# Altium schematic sheet wulianwangwenhengmokuai.SchDoc(wulianwangwenhengmokuai)
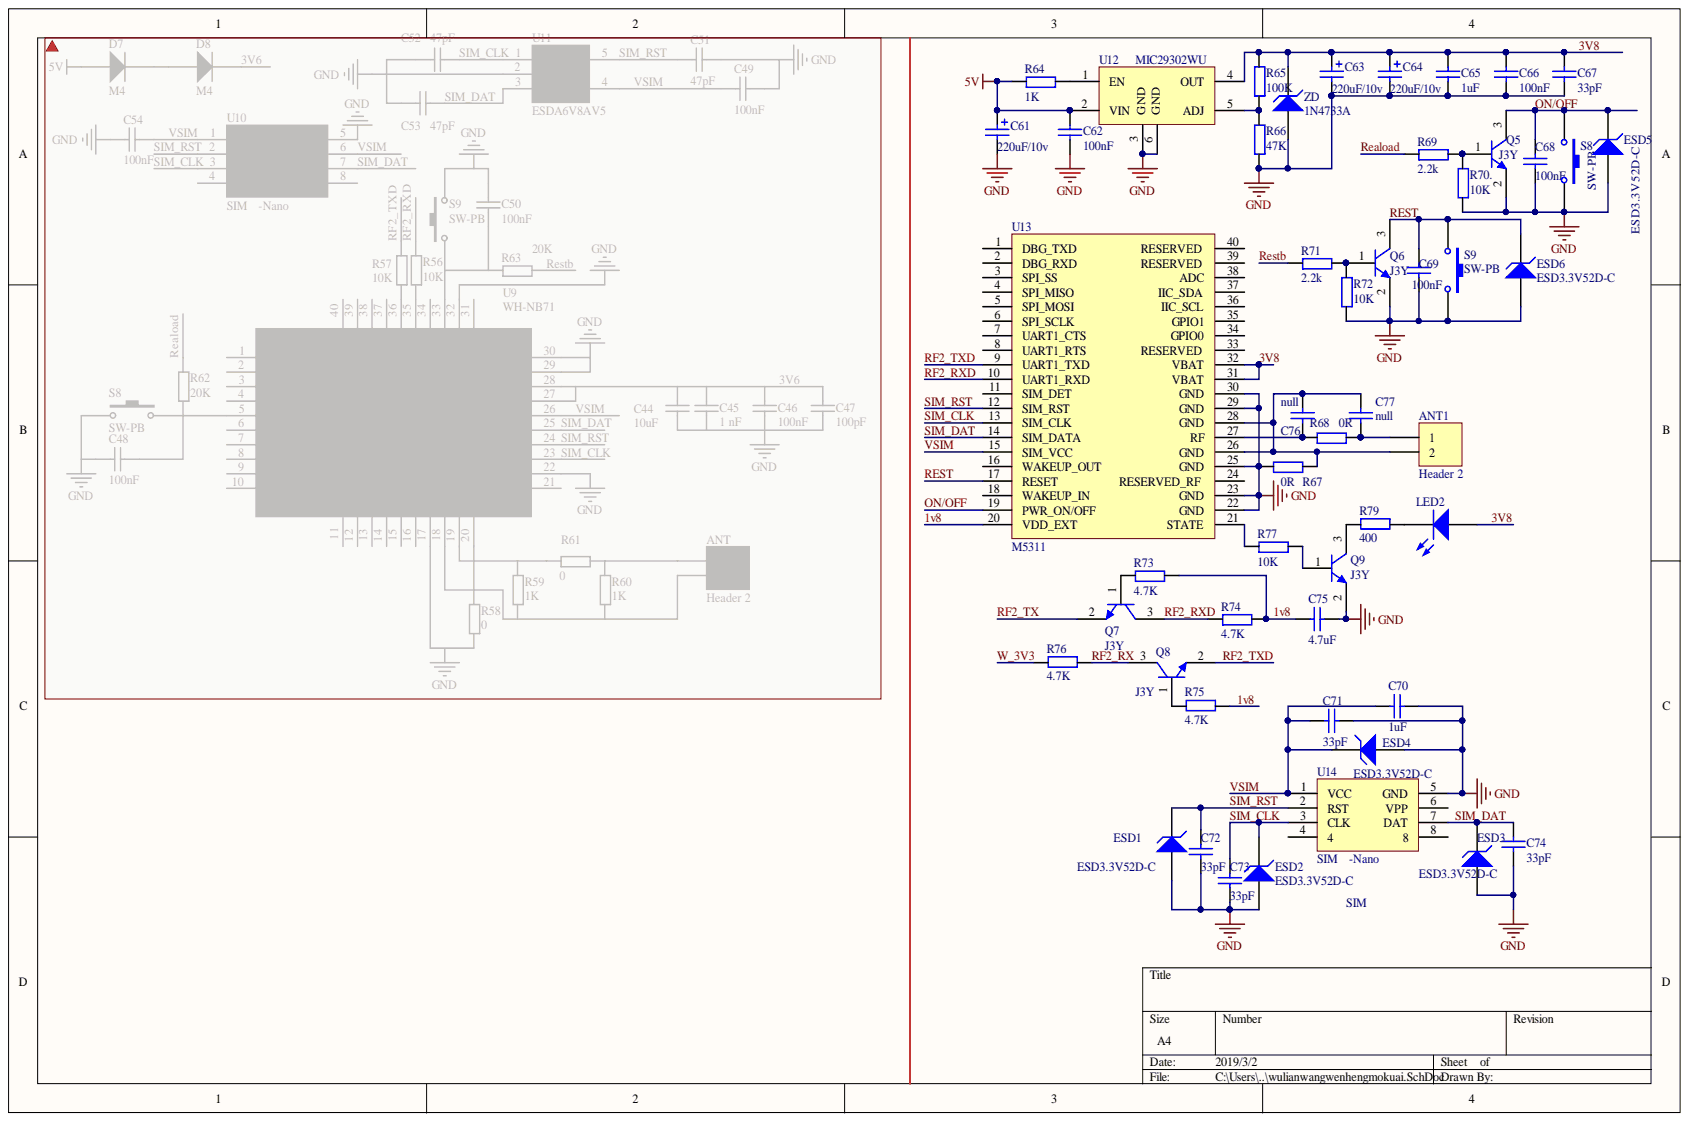
[diagram p0: wulianwangwenhengmokuai.SchDoc]

## Parts
ANT1  Header 2  [SMA-W]
C61  220uF/10v  [C5X8]
C62  100nF  [C0805]
C63  220uF/10v  [C5X8]
C64  220uF/10v  [C5X8]
C65  1uF  [C0805]
C66  100nF  [C0805]
C67  33pF  value 100nF  [C0805]
C68  100nF  [C0603]
C69  100nF  [C0603]
C70  1uF  [C0603]
C71  33pF  [C0603]
C72  33pF  [C0603]
C73  33pF  [C0603]
C74  33pF  [C0603]
C75  4.7uF  [C0603]
C76  null  [C0603]
C77  null  [C0603]
ESD1  ESD3.3V52D-C  [C0603]
ESD2  ESD3.3V52D-C  [C0603]
ESD3  ESD3.3V52D-C  [C0603]
ESD4  ESD3.3V52D-C  [C0603]
ESD5  ESD3.3V52D-C  [C0603]
ESD6  ESD3.3V52D-C  [C0603]
LED2  网络指示  [LED0805]  (value not drawn)
Q5  J3Y  [SOT-23]
Q6  J3Y  [SOT-23]
Q7  J3Y  [SOT-23]
Q8  J3Y  [SOT-23]
Q9  J3Y  [SOT-23]
R64  1K  [R0805]
R65  100K  [R0805]
R66  47K  [R0805]
R67  0R  [R0603]
R68  0R  [R0603]
R69  2.2k  [R0603]
R70.  10K  [R0603]
R71  2.2k  [R0603]
R72  10K  [R0603]
R73  4.7K  [R0603]
R74  4.7K  [R0603]
R75  4.7K  [R0603]
R76  4.7K  [R0603]
R77  10K  [R0603]
R79  400  [R0603]
S8  SW-PB  [3X6X2.5KEY-M]
S9  SW-PB  [3X6X2.5KEY-M]
U12  MIC29302WU  [TO263-5]
U13  M5311  [M5311]
U14  SIM卡-Nano  [SIM-Nano]  (value not drawn)
ZD  1N4733A  [SMA]

## Nets
5V: C61.1 C62.2 R64.1 U12.2(VIN)
GND: C61.2 C62.1 C63.2 C64.2 C65.1 C66.1 C67.1 C68.1 C69.1 C70.1 C71.1 C72.2 C73.2 C74.2 C75.1 ESD1.1 ESD2.1 ESD3.1 ESD4.1 ESD5.1 ESD6.1 Q5.2 Q6.2 Q9.2 R66.1 R67.1 R70..1 R72.1 S8.2 S9.2 U12.3(GND) U12.6(GND) U13.22(GND) U13.23(GND) U13.25(GND) U13.29(GND) U13.30(GND) U14.5(GND) ZD.1
1v8: C75.2 R73.2 R74.2 R75.1 U13.20(VDD_EXT)
3V8: C63.1 C64.1 C65.2 C66.2 C67.2 LED2.1 R65.2 U12.4(OUT) U13.31(VBAT) U13.32(VBAT) ZD.2
ON/OFF: C68.2 ESD5.2 Q5.3 S8.1 U13.19(PWR_ON/OFF)
Reaload: R69.1
REST: C69.2 ESD6.2 Q6.3 S9.1 U13.17(RESET)
Restb: R71.1
RF2_RX: Q8.3 R76.1
RF2_RXD: Q7.3 R74.1 U13.10(UART1_RXD)
RF2_TX: Q7.2
RF2_TXD: Q8.2 U13.9(UART1_TXD)
SIM_CLK: C73.1 ESD2.2 U13.13(SIM_CLK) U14.3(CLK)
SIM_DAT: C74.1 ESD3.2 U13.14(SIM_DATA) U14.7(DAT)
SIM_RST: C72.1 ESD1.2 U13.12(SIM_RST) U14.2(RST)
VSIM: C70.2 C71.2 ESD4.2 U13.15(SIM_VCC) U14.1(VCC)
W_3V3: R76.2
NetANT1_1: ANT1.1 C77.2 R68.2
NetANT1_2: ANT1.2 C76.1 C77.1 R67.2 U13.26(GND) U13.28(GND)
NetC76_2: C76.2 R68.1 U13.27(RF)
NetLED2_2: LED2.2 R79.1
NetQ5_1: Q5.1 R69.2 R70..2
NetQ6_1: Q6.1 R71.2 R72.2
NetQ7_1: Q7.1 R73.1
NetQ8_1: Q8.1 R75.2
NetQ9_1: Q9.1 R77.2
NetQ9_3: Q9.3 R79.2
NetR64_2: R64.2 U12.1(EN)
NetR65_1: R65.1 R66.2 U12.5(ADJ)
NetR77_1: R77.1 U13.21(STATE)
N00050:

Not connected: U13 1(DBG_TXD) 2(DBG_RXD) 3(SPI_SS) 4(SPI_MISO) 5(SPI_MOSI) 6(SPI_SCLK) 7(UART1_CTS) 8(UART1_RTS) 11(SIM_DET) 16(WAKEUP_OUT) 18(WAKEUP_IN) 24(RESERVED_RF) 33(RESERVED) 34(GPIO0) 35(GPIO1) 36(IIC_SCL) 37(IIC_SDA) 38(ADC) 39(RESERVED) 40(RESERVED); U14 4 6(VPP) 8

## Library data of the parts
ANT1 = Header 2: 天线座子，弯头，总长17mm，牙长11mm; Signal Integrity: Connector; LatestRevisionNote: Re-released for DXP Platform.; LatestRevisionDate: 17-Jul-2002; Component Kind: Standard; Publisher: Altium Limited; Library Name: 温湿度第三版.SCHLIB
C61 C63 C64 = Cap Pol1_1: Polarized Capacitor (Radial); Value: 220uF/10v; Simulation: CAP; Signal Integrity: Cap Pol; Published: 8-Jun-2000; PackageReference: RB7.6-15; PackageDescription: Polarized Capacitor (Radial); 2 Leads; LatestRevisionNote: Re-released for DXP Platform.; LatestRevisionDate: 17-Jul-2002; Component Kind: Standard; Publisher: Altium Limited; Library Name: 温湿度第三版.SCHLIB
C62 C66-C69 = Cap: Capacitor; Simulation: CAP; Signal Integrity: Cap; Published: 8-Jun-2000; PackageReference: RAD-0.3; PackageDescription: Radial Cap, Thru-Hole; 2 Leads; 0.3 in Pin Spacing; LatestRevisionNote: Re-released for DXP Platform.; LatestRevisionDate: 17-Jul-2002; Component Kind: Standard; Publisher: Altium Limited; Library Name: 温湿度第三版.SCHLIB
  C62 C66 C68 C69: Value: 100nF
C65 C70-C77 = Cap: Capacitor; Value: 100nF; Simulation: CAP; Signal Integrity: Cap; Published: 8-Jun-2000; PackageReference: RAD-0.3; PackageDescription: Radial Cap, Thru-Hole; 2 Leads; 0.3 in Pin Spacing; LatestRevisionNote: Re-released for DXP Platform.; LatestRevisionDate: 17-Jul-2002; Component Kind: Standard; Publisher: Altium Limited; Library Name: 温湿度第三版.SCHLIB
ESD1-ESD6 = D Zener: ESD保护器件; Simulation: ZENER; Signal Integrity: D Zener; Published: 8-Jun-2000; PackageReference: DIODE-0.7; PackageDescription: Diode, Thru-Hole; 2 Leads; 0.7 in Pin Spacing; Library Name: Miscellaneous Devices.IntLib; LatestRevisionNote: Re-released for DXP Platform.; LatestRevisionDate: 17-Jul-2002; Component Kind: Standard; Publisher: Altium Limited
LED2 = LED2: Typical RED, GREEN, YELLOW, AMBER GaAs LED; Simulation: LED2; Published: 8-Jun-2000; PackageReference: 3.2X1.6X1.1; PackageDocument: Feb-2002; LatestRevisionNote: Re-released for DXP Platform.; LatestRevisionDate: 17-Jul-2002; Component Kind: Standard; Publisher: Altium Limited; Library Name: 战舰STM32开发板底板.IntLib
Q5-Q9 = 2N3904: NPN General Purpose Amplifier; Simulation: 2N3904; Signal Integrity: 2N3904; Published: 8-Jun-2000; PackageVersion: Sep-1998; PackageReference: TO-92A; PackageDescription: TO, Flat Index; 3 In-Line, Axial Leads; Body Dia. 4.5mm; Leads 0.51 x 0.48 mm (max); LatestRevisionNote: PCB Footprint 'BCY-W3/D4.7' replaced; LatestRevisionDate: 15-Jan-2003; DatasheetVersion: 1997; ComponentLink2URL: http://www.fairchildsemi.com/ds/2N%2F2N3904.pdf; ComponentLink2Description: Datasheet; ComponentLink1URL: http://www.fairchildsemi.com/; ComponentLink1Description: Manufacturer Link; Component Kind: Standard; Publisher: Altium Limited; Library Name: 温湿度第三版.SCHLIB
R64-R69 R70. R71-R77 R79 = R: Component Kind: Standard; Library Name: 温湿度第三版.SCHLIB
S8 = SW-PB_1: Switch; Published: 24-Mar-1999; PackageReference: SPST-2; PackageDescription: Switch; 2 Leads; LatestRevisionNote: Re-released for DXP Platform.; LatestRevisionDate: 17-Jul-2002; Component Kind: Standard; Publisher: Altium Limited; Library Name: 温湿度第三版.SCHLIB
S9 = SW-PB: Switch; Published: 24-Mar-1999; PackageReference: SPST-2; PackageDescription: Switch; 2 Leads; LatestRevisionNote: Re-released for DXP Platform.; LatestRevisionDate: 17-Jul-2002; Component Kind: Standard; Publisher: Altium Limited; Library Name: 温湿度第三版.SCHLIB
U12 = power: Component Kind: Standard; Library Name: 温湿度第三版.SCHLIB
ZD = D Zener: Zener Diode,5.1V,1W; Simulation: ZENER; Signal Integrity: D Zener; Published: 8-Jun-2000; PackageReference: DIODE-0.7; PackageDescription: Diode, Thru-Hole; 2 Leads; 0.7 in Pin Spacing; Library Name: Miscellaneous Devices.IntLib; LatestRevisionNote: Re-released for DXP Platform.; LatestRevisionDate: 17-Jul-2002; Component Kind: Standard; Publisher: Altium Limited
U13 = M5311: Component Kind: Standard; Library Name: 温湿度第三版.SCHLIB
U14 = SIM卡-Nano: Component Kind: Standard; Library Name: 温湿度第三版.SCHLIB
